# Altium schematic sheet Hermit_V1.SchDoc
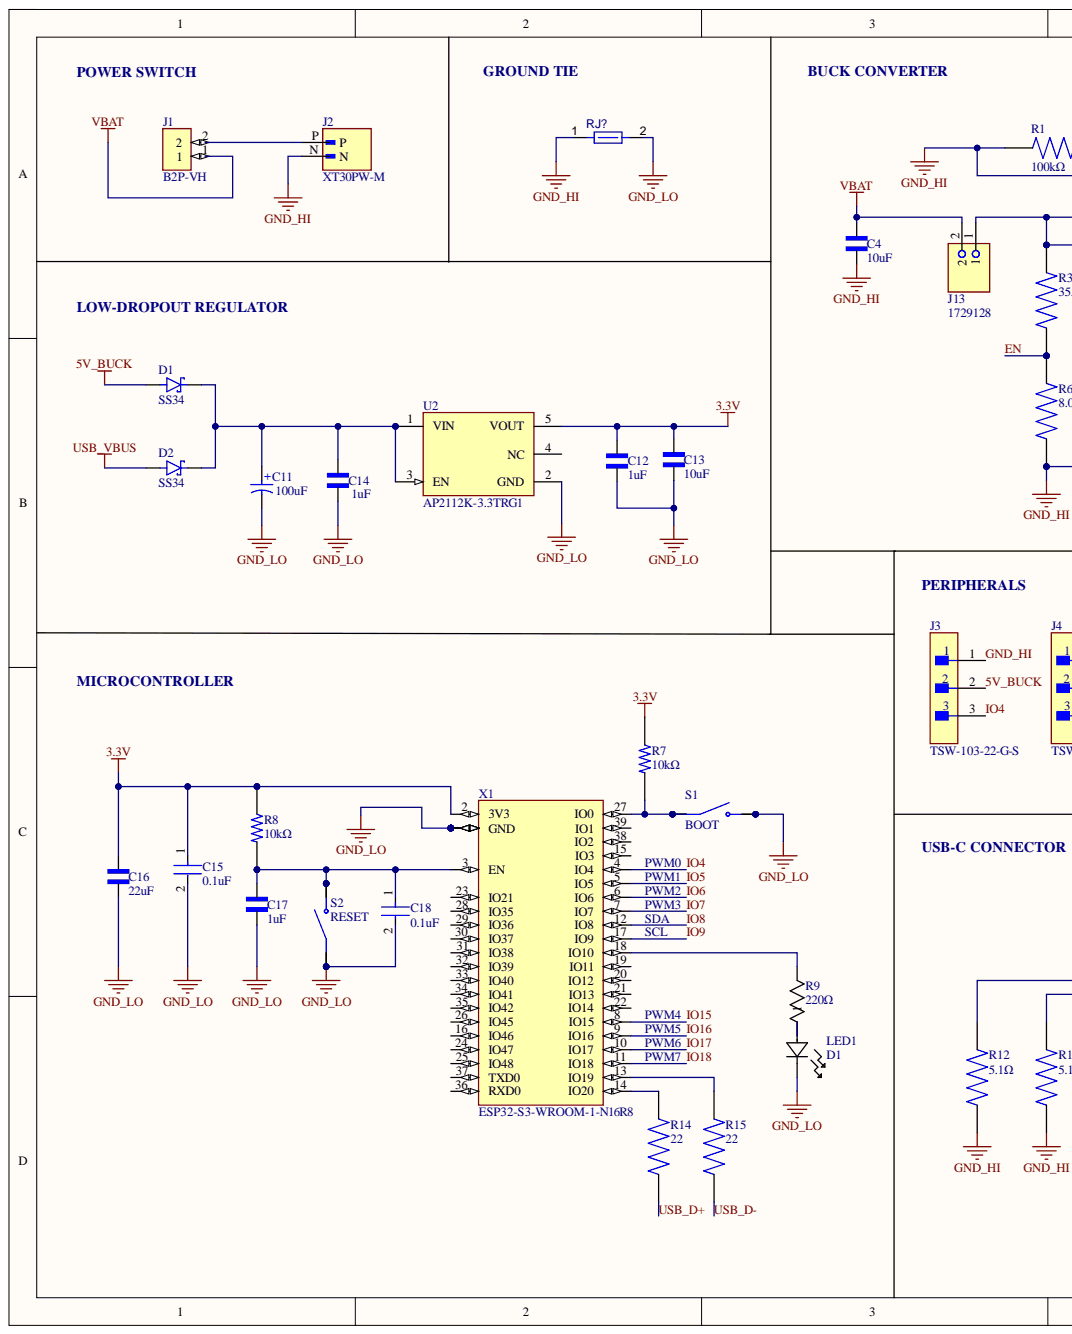
[diagram p0: Hermit_V1.SchDoc, left]
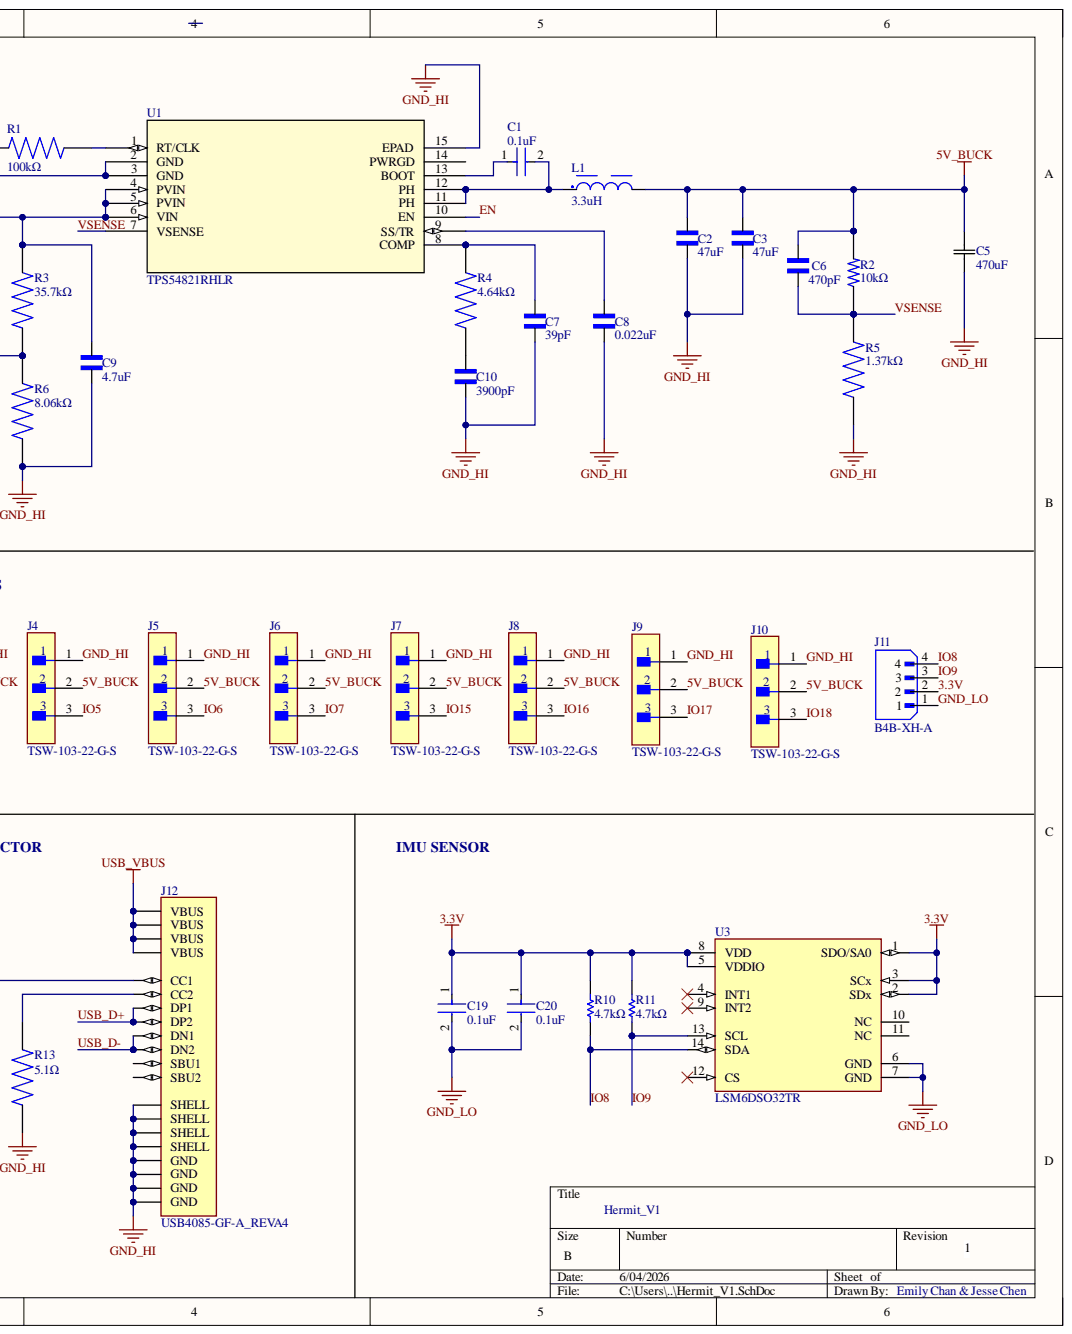
[diagram p1: Hermit_V1.SchDoc, right]

## Parts
C1  0.1uF  [FP-GRM033-0_03-IPC_C]
C2  47uF  [CAPC3225X270N]
C3  47uF  [CAPC3225X270N]
C4  10uF  [CAPC2012X135N]
C5  470uF  [CAP_YX_8X11P5_RUB]
C6  470pF  [CAPC1005X55N]
C7  39pF  [CAPC1005X55N]
C8  0.022uF  [CAPC1608X90N]
C9  4.7uF  [CAPC2012X140N]
C10  3900pF  [CAPC3216X100N]
C11  100µF  [WCAP-ATUL_D5H11]  (value not drawn)
C12  1uF  [CAPC1005X55N]
C13  10uF  [CAPC1005X70N]
C14  1uF  [CAPC1005X55N]
C15  0.1uF  [FP-GRM033-0_03-IPC_C]
C16  22uF  [CAPC1608X100N]
C17  1uF  [CAPC1005X55N]
C18  0.1uF  [FP-GRM033-0_03-IPC_C]
C19  0.1uF  [FP-GRM033-0_03-IPC_C]
C20  0.1uF  [FP-GRM033-0_03-IPC_C]
D1  SS34  [DIOM7959X265N]
D2  SS34  [DIOM7959X265N]
J1  B2P-VH  [B2P-VH_396-2N]
J2  XT30PW-M  [AMASS_XT30UPB-M]
J3  TSW-103-22-G-S  [FP-TSW-103-22-G-S-MFG]
J4  TSW-103-22-G-S  [FP-TSW-103-22-G-S-MFG]
J5  TSW-103-22-G-S  [FP-TSW-103-22-G-S-MFG]
J6  TSW-103-22-G-S  [FP-TSW-103-22-G-S-MFG]
J7  TSW-103-22-G-S  [FP-TSW-103-22-G-S-MFG]
J8  TSW-103-22-G-S  [FP-TSW-103-22-G-S-MFG]
J9  TSW-103-22-G-S  [FP-TSW-103-22-G-S-MFG]
J10  TSW-103-22-G-S  [FP-TSW-103-22-G-S-MFG]
J11  B4B-XH-A  [JST_B4B-XH-A]
J12  USB4085-GF-A_REVA4  [GCT_USB4085-GF-A_REVA4]
J13  1729128  [PHOENIX_1729128]
L1  3.3uH  [WE-LHMI_7030]
LED1  D1  [LED_N1OO-35-1_OSR]
R1  100kΩ  [RESC1608X55N]
R2  10kΩ  [RESC1607X60N]
R3  35.7kΩ  [RESC1608X55N]
R4  4.64kΩ  [RESC1005X35N]
R5  1.37kΩ  [RESC1005X35N]
R6  8.06kΩ  [RESC1608X55N]
R7  10kΩ  [RESC1607X60N]
R8  10kΩ  [RESC1607X60N]
R9  220Ω  [RES_RC0603_YAG]
R10  4.7kΩ  [RESC1005X40X25NL05T10]
R11  4.7kΩ  [RESC1005X40X25NL05T10]
R12  5.1Ω  [RESC1608X55N]
R13  5.1Ω  [RESC1608X55N]
R14  value 22  [RESC3116X65N]  (hidden comment: RC1206JR-0722RL)
R15  value 22  [RESC3116X65N]  (hidden comment: RC1206JR-0722RL)
RJ?  Net Tie 12x8mil  [SHORT06-FP]  (value not drawn)
S1  BOOT  [SW_PTS_647_SM38_SMTR2_LFS]
S2  RESET  [SW_PTS_647_SM38_SMTR2_LFS]
U1  TPS54821RHLR  [RHL14_2P05X2P05]
U2  AP2112K-3.3TRG1  [FP-SOT25-IPC_B]
U3  LSM6DSO32TR  [FP-LGA-14L-DM00249496-MFG]
X1  ESP32-S3-WROOM-1-N16R8  [ESP32S3WROOM1N8R2]

## Nets
USB_VBUS: D2.A J12.A4(VBUS) J12.A9(VBUS) J12.B4(VBUS) J12.B9(VBUS)
VBAT: C4.1 J1.1 J13.2
3.3V: C12.2 C13.2 C15.1 C16.2 C19.1 C20.1 J11.2 R7.1 R8.1 R10.2 R11.2 U2.5(VOUT) U3.1(SDO/SA0) U3.2(SDx) U3.3(SCx) U3.5(VDDIO) U3.8(VDD) X1.2(3V3)
5V_BUCK: C2.2 C3.2 C5.2 C6.2 D1.A J3.2 J4.2 J5.2 J6.2 J7.2 J8.2 J9.2 J10.2 L1.2 R2.2
EN: R3.1 R6.2 U1.10(EN)
GND_HI: C2.1 C3.1 C4.2 C5.1 C7.1 C8.1 C9.1 C10.1 J2.N J3.1 J4.1 J5.1 J6.1 J7.1 J8.1 J9.1 J10.1 J12.A1(GND) J12.A12(GND) J12.B1(GND) J12.B12(GND) J12.S1(SHELL) J12.S2(SHELL) J12.S3(SHELL) J12.S4(SHELL) R1.1 R5.1 R6.1 R12.1 R13.1 RJ?.1 U1.2(GND) U1.3(GND) U1.15(EPAD)
GND_LO: C11.2 C12.1 C13.1 C14.1 C15.2 C16.1 C17.2 C18.2 C19.2 C20.2 J11.1 LED1.1 RJ?.2 S1.1 S1.2 S2.3 S2.4 U2.2(GND) U3.6(GND) U3.7(GND) X1.1(GND) X1.40 X1.41 X1.42 X1.43 X1.44 X1.45 X1.46 X1.47 X1.48 X1.49
IO4: J3.3 X1.4(IO4)
IO5: J4.3 X1.5(IO5)
IO6: J5.3 X1.6(IO6)
IO7: J6.3 X1.7(IO7)
IO8: J11.4 R10.1 U3.14(SDA) X1.12(IO8)
IO9: J11.3 R11.1 U3.13(SCL) X1.17(IO9)
IO15: J7.3 X1.8(IO15)
IO16: J8.3 X1.9(IO16)
IO17: J9.3 X1.10(IO17)
IO18: J10.3 X1.11(IO18)
USB_D+: J12.A6(DP1) J12.B6(DP2) R14.1
USB_D-: J12.A7(DN1) J12.B7(DN2) R15.1
VSENSE: C6.1 R2.1 R5.2 U1.7(VSENSE)
NetC1_1: C1.1 U1.13(BOOT)
NetC1_2: C1.2 L1.1 U1.11(PH) U1.12(PH)
NetC7_2: C7.2 R4.2 U1.8(COMP)
NetC8_2: C8.2 U1.9(SS/TR)
NetC9_2: C9.2 J13.1 R3.2 U1.4(PVIN) U1.5(PVIN) U1.6(VIN)
NetC10_2: C10.2 R4.1
NetC11_1: C11.1 C14.2 D1.C D2.C U2.1(VIN) U2.3(EN)
NetC17_1: C17.1 C18.1 R8.2 S2.1 S2.2 X1.3(EN)
NetJ1_2: J1.2 J2.P
NetJ12_A5: J12.A5(CC1) R12.2
NetJ12_B5: J12.B5(CC2) R13.2
NetLED1_2: LED1.2 R9.1
NetR1_2: R1.2 U1.1(RT/CLK)
NetR7_2: R7.2 S1.3 S1.4 X1.27(IO0)
NetR9_2: R9.2 X1.18(IO10)
NetR14_2: R14.2 X1.14(IO20)
NetR15_2: R15.2 X1.13(IO19)

Not connected: J12 A8(SBU1) B8(SBU2); U1 14(PWRGD); U2 4(NC); U3 4(INT1) 9(INT2) 10(NC) 11(NC) 12(CS); X1 15(IO3) 16(IO46) 19(IO11) 20(IO12) 21(IO13) 22(IO14) 23(IO21) 24(IO47) 25(IO48) 26(IO45) 28(IO35) 29(IO36) 30(IO37) 31(IO38) 32(IO39) 33(IO40) 34(IO41) 35(IO42) 36(RXD0) 37(TXD0) 38(IO2) 39(IO1)

## Library data of the parts
C1 C15 C18-C20 = CMP-2010-01264-2: Chip Multilayer Ceramic Capacitors for General Purpose, 0201, 0.10uF, X5R, 15%, 10%, 10V; Capacitance: 100nF; Case Code (Imperial): 0201; Case Code (Metric): 0603; Case/Package: 0201; Component Kind: Standard; Depth: 0.3mm; Dielectric: X5R; Height: 0.3mm; Lead Free: Lead Free; Length: 0.6mm; Library Name: Altium Content Vault; Max Operating Temperature: 85°C; Min Operating Temperature: -55°C; Mount: Surface Mount; Package Quantity: 1; Packaging: Tape and Reel; Radiation Hardening: No; REACH SVHC: No SVHC; Termination: SMD/SMT; Thickness: 0.013inch; Tolerance: 10%; Voltage: 10V; Voltage Rating: 10V; Voltage Rating (DC): 10V; Weight: 6E-06oz; Width: 0.012inch
C2 C3 = GRM32ER71A476KE15L: SMD capacitor X7R(EIA) with capacitance 47uF Tol.10%. Rated voltage 10Vdc 125C; MF: Murata Electronics; Check_prices: https://www.snapeda.com/parts/GRM32ER71A476KE15L/Murata+Electronics+North+America/view-part/?ref=eda; Component Kind: Standard; Library Name: GRM32ER71A476KE15L.SchLib; MP: GRM32ER71A476KE15L; Package: 3225 Murata; SnapEDA_Link: https://www.snapeda.com/parts/GRM32ER71A476KE15L/Murata+Electronics+North+America/view-part/?ref=snap
C4 = GRM21BR61D106ME15L: SMD Capacitor X5R(EIA) with Capacitance: 10uF Tol. 20%. Rated Voltage: 20Vdc; MF: Murata Electronics; Check_prices: https://www.snapeda.com/parts/GRM21BR61D106ME15L/Murata+Electronics+North+America/view-part/?ref=eda; Component Kind: Standard; Library Name: GRM21BR61D106ME15L.SchLib; MP: GRM21BR61D106ME15L; Package: 805-2 Murata; SnapEDA_Link: https://www.snapeda.com/parts/GRM21BR61D106ME15L/Murata+Electronics+North+America/view-part/?ref=snap
C5 = 16ZLH470MEFCT78X11.5: Description; Manufacturer_Name: Rubycon; Component Kind: Standard; Library Name: 16ZLH470MEFCT78X11P5.SchLib; Manufacturer_Part_Number: 16ZLH470MEFCT78X11.5; Copyright: Copyright (C) 2026 Ultra Librarian. All rights reserved.
C6 = GRM155R71H471KA01D: SMD Capacitor X7R(EIA) with Capacitance: 470pF Tol. 10%. Rated Voltage: 50Vdc; MF: Murata; Check_prices: https://www.snapeda.com/parts/GRM155R71H471KA01D/Murata/view-part/?ref=eda; Component Kind: Standard; Library Name: GRM155R71H471KA01D.SchLib; MP: GRM155R71H471KA01D; Package: 1005-2 Murata; SnapEDA_Link: https://www.snapeda.com/parts/GRM155R71H471KA01D/Murata/view-part/?ref=snap
C7 = CBR04C390F5GAC: MF: {'manufacturer': u'KEMET'}; Availability: Unavailable; Component Kind: Standard; Library Name: CBR04C390F5GAC.SchLib; MP: CBR04C390F5GAC; Package: None; Price: None
C8 = CL10B223KO8WPNC: 0.022 ÂµF Â±10% 16V Ceramic Capacitor X7R 0603 (1608 Metric); MF: Samsung Electro-Mechanics; Check_prices: https://www.snapeda.com/parts/CL10B223KO8WPNC/Samsung/view-part/?ref=eda; Component Kind: Standard; Library Name: CL10B223KO8WPNC.SchLib; MP: CL10B223KO8WPNC; Package: SMD-2 Samsung; SnapEDA_Link: https://www.snapeda.com/parts/CL10B223KO8WPNC/Samsung/view-part/?ref=snap
C9 = CL21A475KAQNNNE: 4.7 ÂµF Â±10% 25V Ceramic Capacitor X5R 0805 (2012 Metric); MF: Samsung Electro-Mechanics; Check_prices: https://www.snapeda.com/parts/CL21A475KAQNNNE/Samsung/view-part/?ref=eda; Component Kind: Standard; Library Name: CL21A475KAQNNNE.SchLib; MP: CL21A475KAQNNNE; Package: SMD-2 Samsung; SnapEDA_Link: https://www.snapeda.com/parts/CL21A475KAQNNNE/Samsung/view-part/?ref=snap
C10 = C1206C392FCGACAUTO: 3900 pF Â±1% 500V Ceramic Capacitor C0G, NP0 1206 (3216 Metric); MF: KEMET; Check_prices: https://www.snapeda.com/parts/C1206C392FCGACAUTO/Kemet/view-part/?ref=eda; Component Kind: Standard; Library Name: C1206C392FCGACAUTO.SchLib; MP: C1206C392FCGACAUTO; Package: 3216 KEMET; SnapEDA_Link: https://www.snapeda.com/parts/C1206C392FCGACAUTO/Kemet/view-part/?ref=snap
C11 = 860040272001: WCAP-ATUL Aluminum Electrolytic Capacitor, Radial, THT, 5mm x 11mm, 100µF, 10V; Manufacturer: Wurth Elektronik; Capacitance Tolerance: 20%; Dissipation Factor: 19%; Endurance: 4000h; Leakage Current: 10µA; Ripple Current: 215mA; Capacitance: 100µF; Category: Aluminum Electrolytic Capacitors; Component Kind: Standard; ComponentLink1Description: Website Link; ComponentLink1URL: https://www.we-online.com/redexpert/article/860040272001?ad; ComponentLink2Description: Datasheet Link; ComponentLink2URL: https://www.we-online.com/redexpert/spec/860040272001?ad; Diameter: 5mm; Height: 11mm; Library Name: WCAP-ATUL.SchLib; Manufacturer Part Number: 860040272001; Match Code: WCAP-ATUL; Max Operating Temperature: 105°C; Min Operating Temperature: -40°C; Mount: Through Hole; Packaging: Ammo Pack; Rated Voltage (DC): 10V; Simulation: 860040272001_100uF
C12 C14 C17 = CL05A105KP5NNNC: 1 ÂµF Â±10% 10V Ceramic Capacitor X5R 0402 (1005 Metric); MF: Samsung; Check_prices: https://www.snapeda.com/parts/CL05A105KP5NNNC/Samsung/view-part/?ref=eda; Component Kind: Standard; Library Name: CL05A105KP5NNNC.SchLib; MP: CL05A105KP5NNNC; Package: SMD-2 Samsung; SnapEDA_Link: https://www.snapeda.com/parts/CL05A105KP5NNNC/Samsung/view-part/?ref=snap
C13 = CL05A106MP5NUNC: 10 ÂµF Â±20% 10V Ceramic Capacitor X5R 0402 (1005 Metric); MF: Samsung; Check_prices: https://www.snapeda.com/parts/CL05A106MP5NUNC/Samsung/view-part/?ref=eda; Component Kind: Standard; Library Name: CL05A106MP5NUNC.SchLib; MP: CL05A106MP5NUNC; Package: SMD-2 Samsung; SnapEDA_Link: https://www.snapeda.com/parts/CL05A106MP5NUNC/Samsung/view-part/?ref=snap
C16 = GRM188R61A226ME15D: SMD capacitor X5R(EIA) with capacitance 22uF Tol.20%. Rated voltage 10Vdc 85C; MF: Murata Electronics; Check_prices: https://www.snapeda.com/parts/GRM188R61A226ME15D/Murata+Electronics+North+America/view-part/?ref=eda; Component Kind: Standard; Library Name: GRM188R61A226ME15D.SchLib; MP: GRM188R61A226ME15D; Package: 1608 Murata; SnapEDA_Link: https://www.snapeda.com/parts/GRM188R61A226ME15D/Murata+Electronics+North+America/view-part/?ref=snap
D1 D2 = SS34: Diode Schottky 40 V 3A Surface Mount SMC (DO-214AB); MF: onsemi; Check_prices: https://www.snapeda.com/parts/SS34/Onsemi/view-part/?ref=eda; Component Kind: Standard; Library Name: SS34.SchLib; MP: SS34; Package: SMC-2 ON Semiconductor; SnapEDA_Link: https://www.snapeda.com/parts/SS34/Onsemi/view-part/?ref=snap
J1 = B2P-VH: 2 Position Adapter Connector Receptacle, Female Sockets To Receptacle, Female Sockets 0.156 (3.96mm); MF: JST Sales America Inc.; Check_prices: https://www.snapeda.com/parts/B2P-VH/JST/view-part/?ref=eda; Component Kind: Standard; Library Name: B2P-VH.SchLib; MP: B2P-VH; Package: None; SnapEDA_Link: https://www.snapeda.com/parts/B2P-VH/JST/view-part/?ref=snap
J2 = XT30UPB-M: Socket; DC supply; XT30; male; PIN: 2; on PCBs; THT; yellow; 15A; 500V; MF: amass; Check_prices: https://www.snapeda.com/parts/XT30UPB-M/AMASS/view-part/?ref=eda; Component Kind: Standard; Library Name: XT30UPB-M.SchLib; MP: XT30UPB-M; Package: None; SnapEDA_Link: https://www.snapeda.com/parts/XT30UPB-M/AMASS/view-part/?ref=snap
J3-J10 = CMP-02766-000544-1: Conn Unshrouded Header HDR 3 POS 2.54mm Solder ST Thru-Hole Bulk; Gender: Male; Max Current Rating: 7.6A; Max Voltage Rating (AC): 550V; Number of Contacts: 3; Component Kind: Standard; Contact Material: Bronze; Contact Plating: Gold; Depth: 2.54mm; Housing Color: Black; Housing Material: Polyester; Length: 7.62mm; Library Name: Altium Content Vault; Max Operating Temperature: 125°C; Min Operating Temperature: -55°C; Number of Rows: 1; Orientation: Straight; Packaging: Bulk; Pitch: 2.54mm; REACH SVHC: No SVHC; Termination: Solder
J11 = B4B-XH-A: Connector Header Through Hole 4 position 0.098 (2.50mm); MF: JST Sales; Check_prices: https://www.snapeda.com/parts/B4B-XH-A/JST/view-part/?ref=eda; Component Kind: Standard; Library Name: B4B-XH-A.SchLib; MP: B4B-XH-A; Package: None; SnapEDA_Link: https://www.snapeda.com/parts/B4B-XH-A/JST/view-part/?ref=snap
J12 = USB4085-GF-A_REVA4: USB-C (USB TYPE-C) USB 2.0 Receptacle Connector 24 (16+8 Dummy) Position Through Hole, Right Angle, low cost, 3.46mm profile, 16 pin, horizontal, Top mount; MF: Global Connector Technology; Availability: In Stock; Check_prices: https://www.snapeda.com/parts/USB4085-GF-A/Global+Connector+Technology/view-part/?ref=eda; Component Kind: Standard; Library Name: USB4085-GF-A_REVA4.SchLib; MP: USB4085-GF-A; Package: None; Price: None; Purchase-URL: https://pricing.snapeda.com/search/part/USB4085-GF-A/?ref=eda; SnapEDA_Link: https://www.snapeda.com/parts/USB4085-GF-A/Global+Connector+Technology/view-part/?ref=snap
J13 = CMP-005-000000-1: PCB Terminal Screw 5.08mm 16AWG 400V 13.5A 2 Position COMBICON MKDSN Series; MF: Phoenix Contact; Check_prices: https://www.snapeda.com/parts/1729128/Phoenix+Contact/view-part/?ref=eda; Component Kind: Standard; Component Type: Standard; Design Item ID: 1729128; MP: 1729128; Package: None; Pin Count: 2; SnapEDA_Link: https://www.snapeda.com/parts/1729128/Phoenix+Contact/view-part/?ref=snap; Source: 1729128.SchLib; Library Name: HermitCrab
L1 = WE-LHMI_7030: 3.3 ÂµH Shielded Molded Inductor 5 A 30mOhm Max 2-SMD; MF: WÃ¼rth Elektronik; MP: 74437346033; Check_prices: https://www.snapeda.com/parts/74437346033/W%25C3%25BCrth+Elektronik+Midcom/view-part/?ref=eda; Component Kind: Standard; Library Name: WE-LHMI_7030.SchLib; Package: Nonstandard WÃ¼rth Elektronik; SnapEDA_Link: https://www.snapeda.com/parts/74437346033/W%25C3%25BCrth+Elektronik+Midcom/view-part/?ref=snap
LED1 = LB Q39E-N1OO-35-1: Description; Manufacturer_Name: ams OSRAM; Component Kind: Standard; Library Name: LBQ39E-N1OO-35-1.SchLib; Manufacturer_Part_Number: LB Q39E-N1OO-35-1; Copyright: Copyright (C) 2026 Ultra Librarian. All rights reserved.
R1 = RT0603CRE07100KL: 100 kOhms Â±0.25% 0.1W, 1/10W Chip Resistor 0603 (1608 Metric) Thin Film; MF: Yageo; Check_prices: https://www.snapeda.com/parts/RT0603CRE07100KL/Yageo/view-part/?ref=eda; Component Kind: Standard; Library Name: RT0603CRE07100KL.SchLib; MP: RT0603CRE07100KL; Package: 1608 Yageo; SnapEDA_Link: https://www.snapeda.com/parts/RT0603CRE07100KL/Yageo/view-part/?ref=snap
R2 R7 R8 = RC0603FR-0710KL: 10 kOhms Â±1% 0.1W, 1/10W Chip Resistor 0603 (1608 Metric) Moisture Resistant Thick Film; MF: Yageo; Check_prices: https://www.snapeda.com/parts/RC0603FR-0710KL/Yageo/view-part/?ref=eda; Component Kind: Standard; Library Name: RC0603FR-0710KL.SchLib; MP: RC0603FR-0710KL; Package: 0603 Yageo; SnapEDA_Link: https://www.snapeda.com/parts/RC0603FR-0710KL/Yageo/view-part/?ref=snap
R3 = RT0603BRD0735K7L: Res Thin Film 0603 35.7K Ohm 0.1% 0.1W(1/10W) Â±25ppm/C Epoxy Pad SMD T/R; MF: Yageo; Check_prices: https://www.snapeda.com/parts/RT0603BRD0735K7L/Yageo/view-part/?ref=eda; Component Kind: Standard; Library Name: RT0603BRD0735K7L.SchLib; MP: RT0603BRD0735K7L; Package: 1608 Yageo; SnapEDA_Link: https://www.snapeda.com/parts/RT0603BRD0735K7L/Yageo/view-part/?ref=snap
R4 = RT0402BRD074K64L: Res Thin Film 0402 4.64K Ohm 0.1% 0.063W(1/16W) Â±25ppm/C Molded SMD Paper T/R; MF: Yageo; Check_prices: https://www.snapeda.com/parts/RT0402BRD074K64L/Yageo/view-part/?ref=eda; Component Kind: Standard; Library Name: RT0402BRD074K64L.SchLib; MP: RT0402BRD074K64L; Package: 1005 Yageo; SnapEDA_Link: https://www.snapeda.com/parts/RT0402BRD074K64L/Yageo/view-part/?ref=snap
R5 = RT0402BRD071K37L: Res Thin Film 0402 1.37K Ohm 0.1% 0.063W(1/16W) Â±25ppm/C Molded SMD Paper T/R; MF: Yageo; Check_prices: https://www.snapeda.com/parts/RT0402BRD071K37L/Yageo/view-part/?ref=eda; Component Kind: Standard; Library Name: RT0402BRD071K37L.SchLib; MP: RT0402BRD071K37L; Package: 1005 Yageo; SnapEDA_Link: https://www.snapeda.com/parts/RT0402BRD071K37L/Yageo/view-part/?ref=snap
R6 = RT0603BRD078K06L: 8.06 kOhms Â±0.1% 0.1W, 1/10W Chip Resistor 0603 (1608 Metric) - Thin Film; MF: Yageo; Check_prices: https://www.snapeda.com/parts/RT0603BRD078K06L/Yageo/view-part/?ref=eda; Component Kind: Standard; Library Name: RT0603BRD078K06L.SchLib; MP: RT0603BRD078K06L; Package: 1608 Yageo; SnapEDA_Link: https://www.snapeda.com/parts/RT0603BRD078K06L/Yageo/view-part/?ref=snap
R9 = RC0603FR-13220RL: Description; Manufacturer_Name: Yageo; Component Kind: Standard; Library Name: RC0603FR-13220RL.SchLib; Manufacturer_Part_Number: RC0603FR-13220RL; Copyright: Copyright (C) 2025 Ultra Librarian. All rights reserved.
R10 R11 = CMP-1011-00586-2: Manufacturer: Yageo / Phycomp; Part Number: RC0402FR-074K7L; Component Kind: Standard; Library Name: Altium Content Vault
R12 R13 = RC0603FR-105K1L: Res Thick Film 0603 5.1K Ohm 1% 0.1W(1/10W) Â±100ppm/Â°C Epoxy Pad SMD T/R; MF: Yageo; Check_prices: https://www.snapeda.com/parts/RC0603FR-105K1L/Yageo/view-part/?ref=eda; Component Kind: Standard; Library Name: RC0603FR-105K1L.SchLib; MP: RC0603FR-105K1L; Package: 0603-2 YAGEO; SnapEDA_Link: https://www.snapeda.com/parts/RC0603FR-105K1L/Yageo/view-part/?ref=snap
R14 R15 = CMP-009-00181-1: 22 Ohms Â±5% 0.25W, 1/4W Chip Resistor 1206 (3216 Metric) Moisture Resistant Thick Film; MF: Yageo; Case/Package: 3116 Yageo; Check_prices: https://www.snapeda.com/parts/RC1206JR-0722RL/Yageo/view-part/?ref=eda; Component Kind: Standard; Component Type: Standard; Design Item ID: RC1206JR-0722RL; MP: RC1206JR-0722RL; Pins: 2; SnapEDA_Link: https://www.snapeda.com/parts/RC1206JR-0722RL/Yageo/view-part/?ref=snap; Source: RC1206JR-0722RL.SchLib; Library Name: HermitCrab
RJ? = CMP-009-00121-2: Component Kind: Net Tie; Description: Net Tie 12x8mil; Max Operating Temperature: 155°C; Min Operating Temperature: -55°C; Pins: 2; Library Name: HermitCrab
S1 S2 = PTS_647_SM38_SMTR2_LFS: Tactile Switch SPST-NO Top Actuated Surface Mount; MF: C&K; MP: PTS 647 SM38 SMTR2 LFS; Check_prices: https://www.snapeda.com/parts/PTS%20647%20SM38%20SMTR2%20LFS/C%2526K/view-part/?ref=eda; Component Kind: Standard; Library Name: PTS_647_SM38_SMTR2_LFS.SchLib; Package: None; SnapEDA_Link: https://www.snapeda.com/parts/PTS%20647%20SM38%20SMTR2%20LFS/C%2526K/view-part/?ref=snap
U1 = TPS54821RHLR: 4.5-V to 17-V, 8-A synchronous buck converter with hiccup current limit 14-VQFN -40 to 125; Manufacturer_Name: Texas Instruments; Component Kind: Standard; Library Name: TPS54821RHLR.SchLib; Manufacturer_Part_Number: TPS54821RHLR; RefDes: RefDes; Type: Type; Copyright: Copyright (C) 2026 Ultra Librarian. All rights reserved.
U2 = CMP-019-00049-1: AP2112 Series 0.6 A 3.3 V Fixed Output SMT LDO Linear Regulator - SOT23-5; Dropout Voltage: 250mV; Max Supply Voltage: 6V; Min Supply Voltage: 2.5V; Nominal Supply Current: 55µA; Output Current: 600mA; Output Voltage: 3.3V; Case/Package: SOT-23-5; Component Kind: Standard; Max Operating Temperature: 85°C; Min Operating Temperature: -40°C; Mounting Technology: Surface Mount; Pins: 5; Library Name: McMaster Exoskeleton
U3 = CMP-010-00024-1: Accelerometer and 3D Gyroscope 3D Always-On 1.71V to 3.6V 0.55mA 14-Pin LGA T/R; Component Kind: Standard; Max Operating Temperature: 85°C; Max Supply Voltage: 3.6V; Min Operating Temperature: -40°C; Min Supply Voltage: 1.71V; Mounting Technology: Surface Mount; Library Name: McMaster Exoskeleton
X1 = ESP32-S3-WROOM-1-N16R8: Bluetooth, WiFi 802.11b/g/n, Bluetooth v5.0 Transceiver Module 2.4GHz PCB Trace Surface Mount; MF: Espressif Systems; Check_prices: https://www.snapeda.com/parts/ESP32-S3-WROOM-1-N16R8/Espressif+Systems/view-part/?ref=eda; Component Kind: Standard; Library Name: ESP32-S3-WROOM-1-N16R8.SchLib; MP: ESP32-S3-WROOM-1-N16R8; Package: NON STANDARD Espressif Systems; SnapEDA_Link: https://www.snapeda.com/parts/ESP32-S3-WROOM-1-N16R8/Espressif+Systems/view-part/?ref=snap
